# Altium schematic sheet MIXR Input System - input.SchDoc
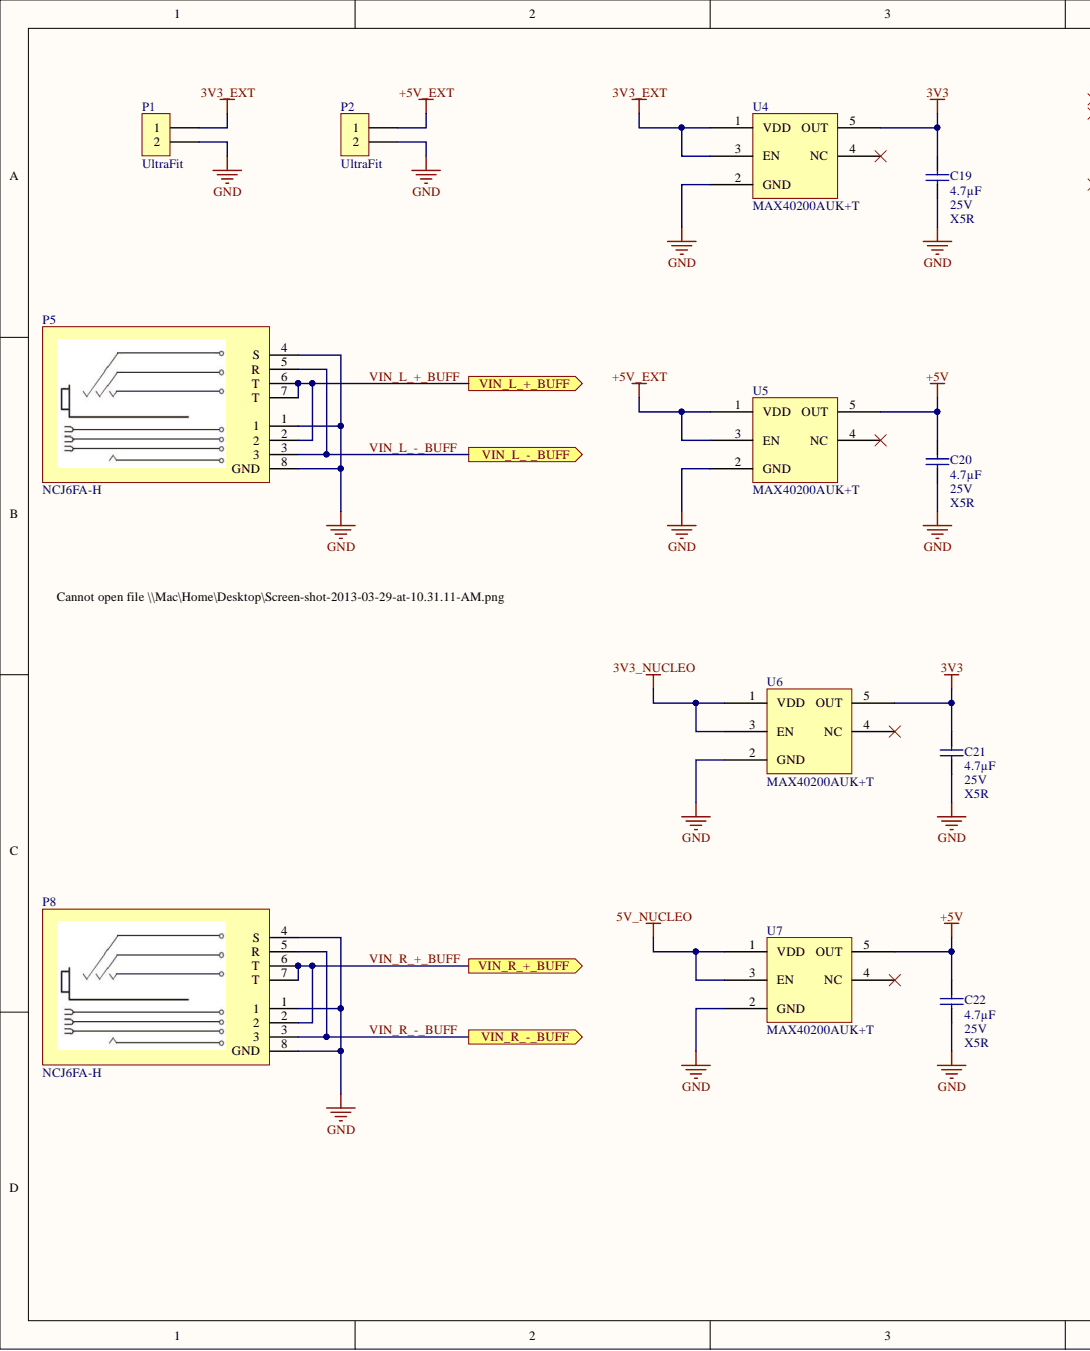
[diagram p0: MIXR Input System - input.SchDoc, left]
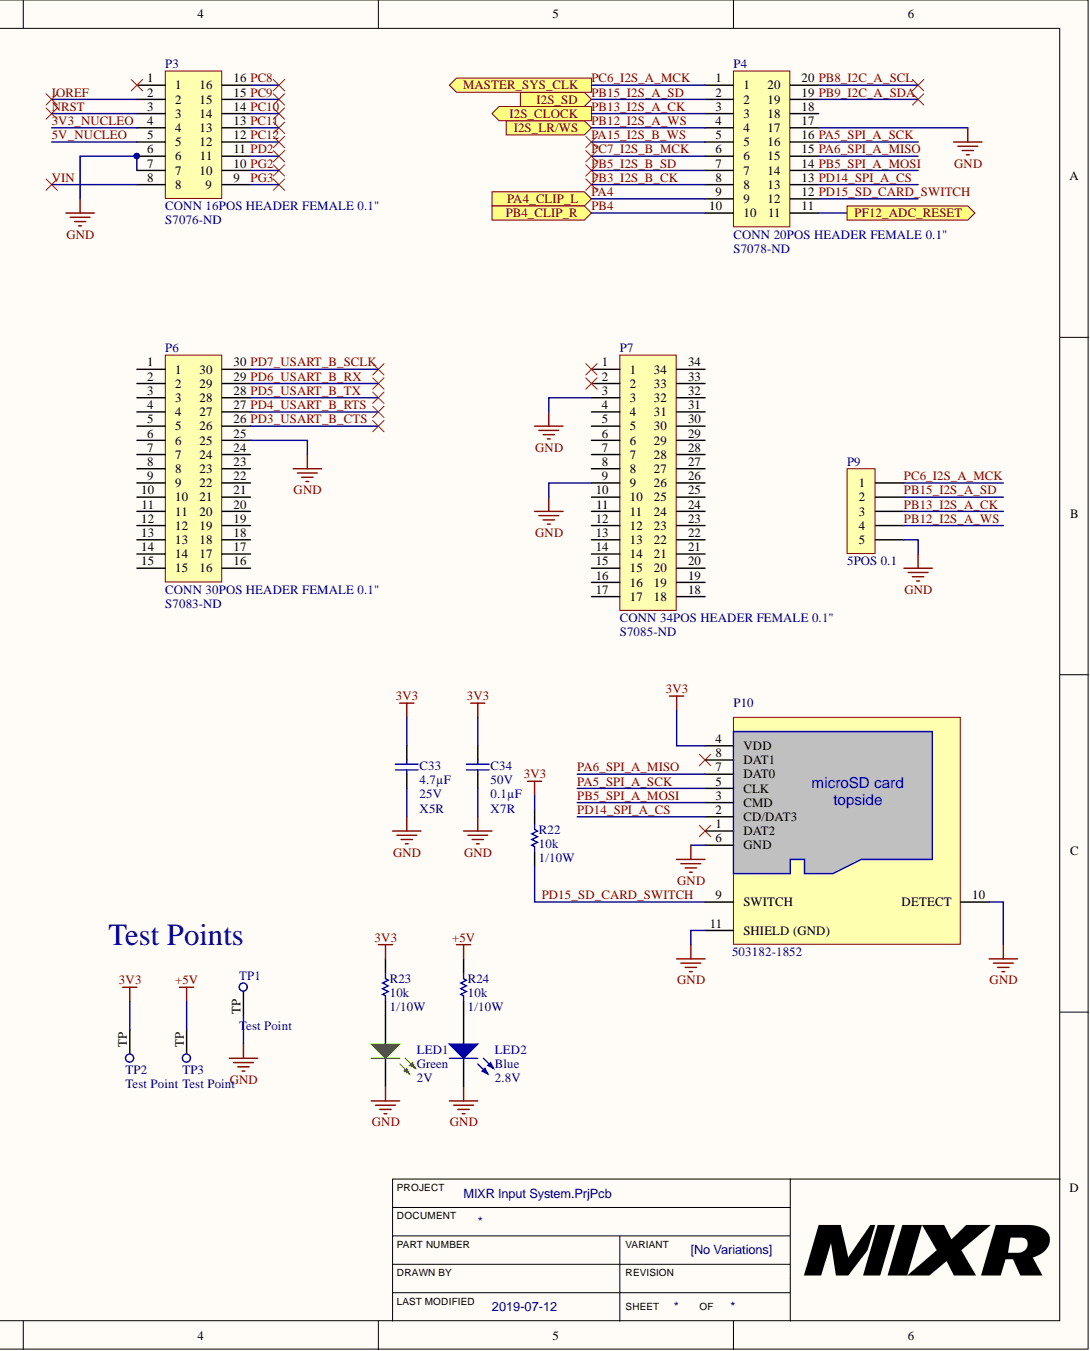
[diagram p1: MIXR Input System - input.SchDoc, right]

## Parts
C19  Capacitance: 4.7µF  Temperature Coefficient: X5R  Voltage: 25V  [CAP, 0603]  (hidden comment: CAP CER 4.7UF 25V 10% X5R 0603)
C20  Capacitance: 4.7µF  Temperature Coefficient: X5R  Voltage: 25V  [CAP, 0603]  (hidden comment: CAP CER 4.7UF 25V 10% X5R 0603)
C21  Capacitance: 4.7µF  Temperature Coefficient: X5R  Voltage: 25V  [CAP, 0603]  (hidden comment: CAP CER 4.7UF 25V 10% X5R 0603)
C22  Capacitance: 4.7µF  Temperature Coefficient: X5R  Voltage: 25V  [CAP, 0603]  (hidden comment: CAP CER 4.7UF 25V 10% X5R 0603)
C33  Capacitance: 4.7µF  Temperature Coefficient: X5R  Voltage: 25V  [CAP, 0603]  (hidden comment: CAP CER 4.7UF 25V 10% X5R 0603)
C34  Capacitance: 0.1µF  Temperature Coefficient: X7R  Voltage: 50V  [CAP, 0603]  (hidden comment: CAP CER 0.1UF 50V 10% X7R 0603)
LED1  Color: Green  Voltage - Forward (Vf) (Typ): 2V  [LED_0603]  (hidden comment: LED GREEN CLEAR 2V 0603)
LED2  Color: Blue  Voltage - Forward (Vf) (Typ): 2.8V  [LED_0603]  (hidden comment: LED BLUE CLEAR 2.8V 0603)
P1  Series: UltraFit  [CONN, 2POS ULTRA-FIT]  (hidden comment: CONN 2POS ULTRA-FIT 0.138")
P2  Series: UltraFit  [CONN, 2POS ULTRA-FIT]  (hidden comment: CONN 2POS ULTRA-FIT 0.138")
P3  CONN 16POS HEADER FEMALE 0.1"  Supplier Part Number 1: S7076-ND  [CONN, 16POS 0.100" Header]
P4  CONN 20POS HEADER FEMALE 0.1"  Supplier Part Number 1: S7078-ND  [CONN, 20POS 0.100" HEADER]
P5  Manufacturer_Part_Number: NCJ6FA-H  [CONN XLR-TRS COMBO 3 POLE]  (hidden comment: CONN XLR-TRS COMBO 3 POLE)
P6  CONN 30POS HEADER FEMALE 0.1"  Supplier Part Number 1: S7083-ND  [CONN, 30POS 0.100" HEADER]
P7  CONN 34POS HEADER FEMALE 0.1"  Supplier Part Number 1: S7085-ND  [CONN, 34POS 0.100" HEADER]
P8  Manufacturer_Part_Number: NCJ6FA-H  [CONN XLR-TRS COMBO 3 POLE]  (hidden comment: CONN XLR-TRS COMBO 3 POLE)
P9  5POS 0.1  [CONN, 5POS HEADR 0.1"]
P10  Manufacturer Part Number: 503182-1852  [CONN MICRO-SD HOLDER MOLEX 503182-1852]  (hidden comment: CONN MICRO-SD ULTRA-LOW 8CKT)
R22  Power: 1/10W  Resistance: 10k  [RES, 0603]  (hidden comment: RES 10K OHM 1% 1/10W 0603)
R23  Power: 1/10W  Resistance: 10k  [RES, 0603]  (hidden comment: RES 10K OHM 1% 1/10W 0603)
R24  Power: 1/10W  Resistance: 10k  [RES, 0603]  (hidden comment: RES 10K OHM 1% 1/10W 0603)
TP1  Test Point  [Test Point]  (no BOM)
TP2  Test Point  [Test Point]  (no BOM)
TP3  Test Point  [Test Point]  (no BOM)
U4  Manufacturer Part Number: MAX40200AUK+T  [SOT95P280X145-5N]  (hidden comment: IC IDEAL DIODE CURRENT SWITCH SOT23-5)
U5  Manufacturer Part Number: MAX40200AUK+T  [SOT95P280X145-5N]  (hidden comment: IC IDEAL DIODE CURRENT SWITCH SOT23-5)
U6  Manufacturer Part Number: MAX40200AUK+T  [SOT95P280X145-5N]  (hidden comment: IC IDEAL DIODE CURRENT SWITCH SOT23-5)
U7  Manufacturer Part Number: MAX40200AUK+T  [SOT95P280X145-5N]  (hidden comment: IC IDEAL DIODE CURRENT SWITCH SOT23-5)

## Ports
I2S_CLOCK, I2S_LR/WS, I2S_SD, MASTER_SYS_CLK, PA4_CLIP_L, PB4_CLIP_R, PF12_ADC_RESET, VIN_L_+_BUFF, VIN_L_-_BUFF, VIN_R_+_BUFF, VIN_R_-_BUFF

## Nets
3V3: C19.1 C21.1 C33.1 C34.2 P10.4(VDD) R22.2 R23.1 TP2.TP U4.5(OUT) U6.5(OUT)
3V3_EXT: P1.1 U4.1(VDD) U4.3(EN)
+5V: C20.1 C22.1 R24.1 TP3.TP U5.5(OUT) U7.5(OUT)
+5V_EXT: P2.1 U5.1(VDD) U5.3(EN)
GND: C19.2 C20.2 C21.2 C22.2 C33.2 C34.1 LED1.2 LED2.2 P1.2 P2.2 P3.6 P3.7 P4.17 P5.1 P5.4(S) P5.8(GND) P6.25 P7.3 P7.9 P8.1 P8.4(S) P8.8(GND) P9.5 P10.6(GND) P10.10(DETECT) P10.11(SHIELD_(GND)) TP1.TP U4.2(GND) U5.2(GND) U6.2(GND) U7.2(GND)
3V3_NUCLEO: P3.4 U6.1(VDD) U6.3(EN)
5V_NUCLEO: P3.5 U7.1(VDD) U7.3(EN)
IOREF: P3.2
NRST: P3.3
PA4: P4.9 ; port PA4_CLIP_L
PA5_SPI_A_SCK: P4.16 P10.5(CLK)
PA6_SPI_A_MISO: P4.15 P10.7(DAT0)
PA15_I2S_B_WS: P4.5
PB3_I2S_B_CK: P4.8
PB4: P4.10 ; port PB4_CLIP_R
PB5_I2S_B_SD: P4.7
PB5_SPI_A_MOSI: P4.14 P10.3(CMD)
PB8_I2C_A_SCL: P4.20
PB9_I2C_A_SDA: P4.19
PB12_I2S_A_WS: P4.4 P9.4 ; port I2S_LR/WS
PB13_I2S_A_CK: P4.3 P9.3 ; port I2S_CLOCK
PB15_I2S_A_SD: P4.2 P9.2 ; port I2S_SD
PC6_I2S_A_MCK: P4.1 P9.1 ; port MASTER_SYS_CLK
PC7_I2S_B_MCK: P4.6
PC8: P3.16
PC9: P3.15
PC10: P3.14
PC11: P3.13
PC12: P3.12
PD2: P3.11
PD3_USART_B_CTS: P6.26
PD4_USART_B_RTS: P6.27
PD5_USART_B_TX: P6.28
PD6_USART_B_RX: P6.29
PD7_USART_B_SCLK: P6.30
PD14_SPI_A_CS: P4.13 P10.2(CD/DAT3)
PD15_SD_CARD_SWITCH: P4.12 P10.9(SWITCH) R22.1
PF12_ADC_RESET: P4.11 ; port PF12_ADC_RESET
PG2: P3.10
PG3: P3.9
VIN: P3.8
VIN_L_+_BUFF: P5.2 P5.6(T) P5.7(T) ; port VIN_L_+_BUFF
VIN_L_-_BUFF: P5.3 P5.5(R) ; port VIN_L_-_BUFF
VIN_R_+_BUFF: P8.2 P8.6(T) P8.7(T) ; port VIN_R_+_BUFF
VIN_R_-_BUFF: P8.3 P8.5(R) ; port VIN_R_-_BUFF
NetLED1_1: LED1.1 R23.2
NetLED2_1: LED2.1 R24.2

Not connected: P3 1; P4 18; P6 1 2 3 4 5 6 7 8 9 10 11 12 13 14 15 16 17 18 19 20 21 22 23 24; P7 1 2 4 5 6 7 8 10 11 12 13 14 15 16 17 18 19 20 21 22 23 24 25 26 27 28 29 30 31 32 33 34; P10 1(DAT2) 8(DAT1); U4 4(NC); U5 4(NC); U6 4(NC); U7 4(NC)

## Library data of the parts
C19-C22 C33 = CAP CER 4.7UF 25V 10% X5R 0603: 4.7µF ±10% 25V Ceramic Capacitor X5R 0603 (1608 Metric); Manufacturer 1: Murata Electronics North America; Manufacturer Part Number 1: GRM188R61E475KE11D; Component Kind: Standard; ComponentLink1Description: http://www.murata.com/~/media/webrenewal/support/library/catalog/products/capacitor/mlcc/c02e.ashx; ComponentLink1URL: http://www.murata.com/~/media/webrenewal/support/library/catalog/products/capacitor/mlcc/c02e.ashx; Height - Seated (Max): -; Lead Spacing: -; Lead Style: -; Mounting Type: Surface Mount, MLCC; Operating Temperature: -55°C ~ 85°C; Package / Case: 0603 (1608 Metric); Packaging: Cut Tape (CT); Part Status: Active; Ratings: -; Size / Dimension: 0.063" L x 0.031" W (1.60mm x 0.80mm); Supplier 1: Digi-Key; Supplier Part Number 1: 490-7203-1-ND; Thickness (Max): 0.031" (0.80mm); Tolerance: ±10%
  C19-C22: Library Name: Schematic Diagrams.SchLib
C34 = CAP CER 0.1UF 50V 10% X7R 0603: 0.10µF ±10% 50V Ceramic Capacitor X7R 0603 (1608 Metric); Manufacturer 1: AVX Corporation; Manufacturer Part Number 1: 06035C104KAT2A; Component Kind: Standard; ComponentLink1Description: http://datasheets.avx.com/X7RDielectric.pdf; ComponentLink1URL: http://datasheets.avx.com/X7RDielectric.pdf; Height - Seated (Max): -; Lead Spacing: -; Lead Style: -; Mounting Type: Surface Mount, MLCC; Operating Temperature: -55°C ~ 125°C; Package / Case: 0603 (1608 Metric); Packaging: Cut Tape (CT); Part Status: Active; Ratings: -; Size / Dimension: 0.063" L x 0.032" W (1.60mm x 0.81mm); Supplier 1: Digi-Key; Supplier Part Number 1: 478-5052-1-ND; Thickness (Max): 0.035" (0.90mm); Tolerance: ±10%; Library Name: Schematic Diagrams.SchLib
LED1 = LED GREEN CLEAR 2V 0603: Green 572nm LED Indication - Discrete 2V 0603 (1608 Metric); Manufacturer: Wurth Electronics Inc.; Manufacturer Part Number: 150060VS75000; Current - Test: 20mA; Lens Color: Colorless; Lens Style/Size: Rectangle with Flat Top, 1.10mm x 0.70mm; Millicandela Rating: 40mcd; Viewing Angle: 140°; Wavelength - Dominant: 570nm; Category: Optoelectronics; Component Kind: Standard; ComponentLink1Description: http://katalog.we-online.de/led/datasheet/150060VS75000.pdf; ComponentLink1URL: http://katalog.we-online.de/led/datasheet/150060VS75000.pdf; Features: -; Height (Max): 0.80mm; Lens Transparency: Clear; Mounting Type: Surface Mount; Package / Case: 0603 (1608 Metric); Packaging: Cut Tape (CT); Part Status: Active; Pricing 1: 1=0.21, 100=0.1617, 500=0.13964 (CAD); RoHS: RoHS Compliant; Size / Dimension: 1.60mm L x 0.80mm W; Stock 1: 9408; Supplier 1: Digi-Key; Supplier Device Package: 0603; Supplier Part Number 1: 732-4980-1-ND; Wavelength - Peak: 572nm; Library Name: Schematic Diagrams.SchLib
LED2 = LED BLUE CLEAR 2.8V 0603: Blue 470nm LED Indication - Discrete 2.8V 0603 (1608 Metric); Manufacturer 1: Lite-On Inc.; Manufacturer Part Number 1: LTST-C193TBKT-5A; Current - Test: 5mA; Lens Color: Colorless; Lens Style/Size: Rectangle with Flat Top, 1.15mm x 0.80mm; Millicandela Rating: 15mcd; Viewing Angle: 130°; Wavelength - Peak: 468nm; Component Kind: Standard; ComponentLink1Description: http://optoelectronics.liteon.com/upload/download/DS22-2004-060/P_100_LTST-C193TBKT-5A.pdf; ComponentLink1URL: http://optoelectronics.liteon.com/upload/download/DS22-2004-060/P_100_LTST-C193TBKT-5A.pdf; Height (Max): 0.45mm; Lens Transparency: Clear; Mounting Type: Surface Mount; Package / Case: 0603 (1608 Metric); Packaging: Cut Tape (CT); Part Status: Active; Size / Dimension: 1.60mm L x 0.80mm W; Supplier 1: Digi-Key; Supplier Device Package: Chip LED; Supplier Part Number 1: 160-1827-1-ND; Wavelength - Dominant: 470nm; Library Name: Schematic Diagrams.SchLib
P1 P2 = CONN 2POS ULTRA-FIT 0.138": Connector Header Through Hole 2 position 0.138" (3.50mm); Manufacturer: Molex; Manufacturer Part Number: 1722871102; Connector Type: Male Pin; Number of Positions: 2; Pitch: 0.138inch; Color: Black; Component Kind: Standard; ComponentLink1Description: http://www.molex.com/pdm_docs/sd/1722861302_sd.pdf; ComponentLink1URL: http://www.molex.com/pdm_docs/sd/1722861302_sd.pdf; Contact Plating: Tin; Fastening Type: Latch; Mount: Through Hole; Number of Rows: 1; Packaging: Tray; Pricing 1: 1 = 0.78000, 10 = 0.68800, 100 = 0.59380, 500 = 0.48582, 1000 = 0.43184, 5000 = 0.37786, 10000 = 0.35897 (USD); RoHS: Yes; Stock 1: 2743; Supplier 1: Digi-Key; Supplier Part Number 1: WM11701-ND; Termination: Solder; Library Name: Schematic Diagrams.SchLib
P3 = CONN 16POS HEADER FEMALE 0.1": 16 Position Header Connector 0.100" (2.54mm) Through Hole Tin; Manufacturer: Sullins; Manufacturer Part Number: PPTC082LFBN-RC; Number of Positions: 16; Pitch: 0.1inch; Color: Black; Component Kind: Standard; ComponentLink1Description: http://www.sullinscorp.com/catalogs/101_Page80-81_HEADER_.100_2.54mm_FEMALE.pdf?src-supplier=Future+Electronics; ComponentLink1URL: http://www.sullinscorp.com/catalogs/101_Page80-81_HEADER_.100_2.54mm_FEMALE.pdf?src-supplier=Future+Electronics; ComponentLink2Description: https://drawings-pdf.s3.amazonaws.com/10492.pdf?src-supplier=Digi-Key; ComponentLink2URL: https://drawings-pdf.s3.amazonaws.com/10492.pdf?src-supplier=Digi-Key; Connector Type: Header; Contact Material: Bronze; Contact Plating: Tin; Height: 8.5mm; Housing Material: Plastic; Lead Free: Lead Free; Length: 20.8mm; Mount: Through Hole; Number of Rows: 2; Package Quantity: 1000; Packaging: Bulk; Pricing 1: 1 = 0.99000, 10 = 0.87000, 100 = 0.75040, 500 = 0.61398, 1000 = 0.54576, 5000 = 0.49270 (USD); RoHS: Yes; Row Spacing: 0.1inch; Stock 1: 4232; Supplier 1: Digi-Key; Termination: Solder; Width: 5mm
P4 = CONN 20POS HEADER FEMALE 0.1": 20 Position Header Connector 0.100" (2.54mm) Through Hole Tin; Manufacturer: Sullins; Manufacturer Part Number: PPTC102LFBN-RC; Number of Positions: 20; Pitch: 0.1inch; Color: Black; Component Kind: Standard; ComponentLink1Description: http://www.sullinscorp.com/catalogs/101_Page80-81_HEADER_.100_2.54mm_FEMALE.pdf?src-supplier=Future+Electronics; ComponentLink1URL: http://www.sullinscorp.com/catalogs/101_Page80-81_HEADER_.100_2.54mm_FEMALE.pdf?src-supplier=Future+Electronics; ComponentLink2Description: https://media.digikey.com/pdf/Data%20Sheets/Sullins%20PDFs/Female_Headers.100_DS.pdf?src-supplier=Digi-Key; ComponentLink2URL: https://media.digikey.com/pdf/Data%20Sheets/Sullins%20PDFs/Female_Headers.100_DS.pdf?src-supplier=Digi-Key; Connector Type: Header; Contact Material: Bronze; Contact Plating: Tin; Height: 8.5mm; Housing Material: Plastic; Lead Free: Lead Free; Length: 25.9mm; Mount: Through Hole; Number of Rows: 2; Package Quantity: 1000; Packaging: Bulk; Pricing 1: 1 = 1.30000, 10 = 1.14500, 100 = 0.98800, 500 = 0.80838, 1000 = 0.71856, 5000 = 0.64870 (USD); RoHS: Yes; Row Spacing: 0.1inch; Stock 1: 4161; Supplier 1: Digi-Key; Termination: Solder; Width: 5mm
P5 P8 = CONN XLR-TRS COMBO 3 POLE: XLR Connectors A SERIES COMBO;3POLE FEM;PCB HOR; Manufacturer: Neutrik; Body Material: Metal; Connector Type: Socket; Current Rating: 3A; Gender: Female; Number of Contacts: 6; Number of Ports: 1; Number of Positions: 3; Voltage Rating: 50V; Case/Package: Metal; Color: Black; Component Kind: Standard; ComponentLink1Description: https://datasheet.ciiva.com/30126/neutrik-ncj6fa-h-1-30126129.pdf?src-supplier=Onlinecomponents.com; ComponentLink1URL: https://datasheet.ciiva.com/30126/neutrik-ncj6fa-h-1-30126129.pdf?src-supplier=Onlinecomponents.com; ComponentLink2Description: https://datasheet.ciiva.com/8008/76755-8008003.pdf?src-supplier=Element14; ComponentLink2URL: https://datasheet.ciiva.com/8008/76755-8008003.pdf?src-supplier=Element14; ComponentLink3Description: https://datasheet.ciiva.com/24394/neutrik-ncj6fa-h-1-24394955.pdf?src-supplier=Onlinecomponents.com; ComponentLink3URL: https://datasheet.ciiva.com/24394/neutrik-ncj6fa-h-1-24394955.pdf?src-supplier=Onlinecomponents.com; Contact Material: Bronze; Contact Plating: Gold; Datasheet Link: http://www.neutrik.com/zoolu-website/media/download/13540/Product+Guide+-+Section+XLR; Depth: 30.2mm; Flammability Rating: UL94 HB; Housing Material: Polyamide; Length: 25mm; Manufacturer_Name: Neutrik; Manufacturer Part Number: NCJ6FA-H; Max Operating Temperature: 80°C; Min Operating Temperature: -30°C; Mouser Part Number: 568-NCJ6FA-H; Mouser Price/Stock: https://www.mouser.com/Search/Refine.aspx?Keyword=568-NCJ6FA-H; Number of Pins: 3; Number of Terminals: 6; Orientation: Horizontal; Package Quantity: 10; Pricing 1: 1 = 2.06000, 10 = 1.96000, 50 = 1.86000, 100 = 1.80000, 1000 = 1.77000, 10000 = 1.77000 (USD); REACH SVHC: No SVHC; RoHS: Yes; Stock 1: 3848; Supplier 1: Mouser; Supplier Part Number 1: 568-NCJ6FA-H; Termination: Solder; Weight: 0.388014oz; Library Name: Schematic Diagrams.SchLib
P6 = CONN 30POS HEADER FEMALE 0.1": 30 Position Header Connector 0.100" (2.54mm) Through Hole Tin; Manufacturer: Sullins; Manufacturer Part Number: PPTC152LFBN-RC; Number of Positions: 30; Pitch: 0.1inch; Color: Black; Component Kind: Standard; ComponentLink1Description: https://media.digikey.com/pdf/Data%20Sheets/Sullins%20PDFs/Female_Headers.100_DS.pdf?src-supplier=Digi-Key; ComponentLink1URL: https://media.digikey.com/pdf/Data%20Sheets/Sullins%20PDFs/Female_Headers.100_DS.pdf?src-supplier=Digi-Key; Connector Type: Header; Contact Material: Bronze; Contact Plating: Tin; Height: 8.5mm; Housing Material: Plastic; Lead Free: Lead Free; Length: 38.6mm; Mount: Through Hole; Number of Rows: 2; Package Quantity: 1000; Packaging: Bulk; Pricing 1: 1 = 1.82000, 10 = 1.65200, 100 = 1.41560, 500 = 1.17968, 1000 = 1.01115, 5000 = 0.97370 (USD); RoHS: Yes; Row Spacing: 0.1inch; Stock 1: 3515; Supplier 1: Digi-Key; Termination: Solder; Width: 5mm
P7 = CONN 34POS HEADER FEMALE 0.1": 34 Position Header Connector 0.100" (2.54mm) Through Hole Tin; Manufacturer: Sullins; Manufacturer Part Number: PPTC172LFBN-RC; Number of Positions: 34; Pitch: 0.1inch; Color: Black; Component Kind: Standard; ComponentLink1Description: https://media.digikey.com/pdf/Data%20Sheets/Sullins%20PDFs/Female_Headers.100_DS.pdf?src-supplier=Digi-Key; ComponentLink1URL: https://media.digikey.com/pdf/Data%20Sheets/Sullins%20PDFs/Female_Headers.100_DS.pdf?src-supplier=Digi-Key; Connector Type: Header; Contact Material: Bronze; Contact Plating: Tin; Height: 8.5mm; Housing Material: Plastic; Lead Free: Lead Free; Length: 43.7mm; Mount: Through Hole; Number of Rows: 2; Package Quantity: 1000; Packaging: Bulk; Pricing 1: 1 = 1.94000, 10 = 1.76400, 100 = 1.51200, 500 = 1.26000, 1000 = 1.08000, 5000 = 1.04000 (USD); RoHS: Yes; Row Spacing: 0.1inch; Stock 1: 1849; Supplier 1: Digi-Key; Termination: Solder; Width: 5mm
P9 = CONN 5POS HEADR MALE 0.1in: 5 Positions Header, Breakaway Connector 0.100" (2.54mm) Through Hole Tin; Manufacturer 1: Molex; Manufacturer Part Number 1: 0022284050; Connector Type: Male Pin; Contact Resistance: 20mR; Description 1: Conn Unshrouded Header HDR 5 POS 2.54mm Solder ST Thru-Hole KK® Bag; Gender: Male; Max Voltage Rating (AC): 250V; Number of Contacts: 5; Colour: Black; Component Kind: Standard; ComponentLink1Description: https://datasheet.ciiva.com/6814/022284363-sd-6814049.pdf; ComponentLink1URL: https://datasheet.ciiva.com/6814/022284363-sd-6814049.pdf; ComponentLink2Description: https://datasheet.ciiva.com/14804/179390022284050-pcb-headers-14804361.pdf; ComponentLink2URL: https://datasheet.ciiva.com/14804/179390022284050-pcb-headers-14804361.pdf; ComponentLink3Description: https://datasheet.ciiva.com/15217/getdatasheetpartid-256138-15217709.pdf; ComponentLink3URL: https://datasheet.ciiva.com/15217/getdatasheetpartid-256138-15217709.pdf; Contact Material: Bronze,Brass; Contact Mating Length: 0.24inch; Contact Plating: Nickel,Tin; Depth: 2.49mm; Housing Colour: Black; Housing Material: Nylon; Length: 12.7mm; Max Operating Temperature: 75°C; Max Voltage Rating (DC): 250V; Min Operating Temperature: 0°C; Mount: Through Hole; Number of Positions: 5; Number of Rows: 1; Orientation: Straight; Packaging: Bulk; Pitch: 2.54mm; Pricing 1: 1=0.22, 10=0.21, 25=0.1796, 50=0.157, 100=0.1459, 250=0.129, 500=0.1234 (USD); Stock 1: 3161; Supplier 1: Digi-Key; Supplier Part Number 1: WM50014-05-ND; Termination: Solder
P10 = CONN MICRO-SD ULTRA-LOW 8CKT: 9 (8 + 1) Position Card Connector microSD™ Surface Mount, Right Angle Gold; Manufacturer: Molex; Gender: Male; Number of Circuits: 8; Pitch: 1.1mm; Component Kind: Standard; Connector Type: Connector; Contact Material: Copper Alloy; Contact Plating: Gold; Depth: 14.95mm; Halogen Free: Low Halogen; Housing Material: Polymer; Length: 15.2mm; Max Operating Temperature: 85°C; Min Operating Temperature: -25°C; Mount: Surface Mount; Number of Rows: 1; Orientation: Right Angle; Package Quantity: 1600; Packaging: Tape and Reel; Pricing 1: 1 = 2.45000, 10 = 2.22400, 25 = 2.08840, 50 = 1.99740, 100 = 1.90670, 250 = 1.72508, 500 = 1.58890 (USD); REACH SVHC: No SVHC; RoHS: Yes; Stock 1: 36042; Supplier 1: Digi-Key; Supplier Part Number 1: WM12834CT-ND; Termination: Solder
R22-R24 = RES 10K OHM 1% 1/10W 0603: RES SMD 10K OHM 1% 1/10W 0603; Manufacturer 1: Yageo; Manufacturer Part Number 1: RC0603FR-0710KL; Temperature Coefficient: ±100ppm/°C; Component Kind: Standard; ComponentLink1Description: http://www.yageo.com/documents/recent/PYu-RC_Group_51_RoHS_L_7.pdf; ComponentLink1URL: http://www.yageo.com/documents/recent/PYu-RC_Group_51_RoHS_L_7.pdf; Composition: Thick Film; Failure Rate: -; Height - Seated (Max): 0.022" (0.55mm); Operating Temperature: -55°C ~ 155°C; Package / Case: 0603 (1608 Metric); Packaging: Cut Tape (CT); Part Status: Active; Size / Dimension: 0.063" L x 0.031" W (1.60mm x 0.80mm); Supplier 1: Digi-Key; Supplier Device Package: 0603; Supplier Part Number 1: 311-10.0KHRCT-ND; Tolerance: ±1%
  R23 R24: Library Name: Schematic Diagrams.SchLib
U4-U7 = IC IDEAL DIODE CURRENT SWITCH SOT23-5: OR Controller Source Selector Switch P-Channel 1:1 SOT-23-5; Manufacturer: Maxim; Component Kind: Standard; ComponentLink1Description: Datasheet; ComponentLink1URL: https://datasheets.maximintegrated.com/en/ds/MAX40200.pdf; Pricing 1: 1 = 0.93000, 10 = 0.82100, 100 = 0.62930, 500 = 0.49750, 1000 = 0.39799 (USD); Stock 1: 7780; Supplier 1: Digi-Key; Supplier Part Number 1: MAX40200AUK+TCT-ND
TP1-TP3 = Test Point: Library Name: Schematic Diagrams.SchLib
